# Altium schematic sheet Sheet1.SchDoc
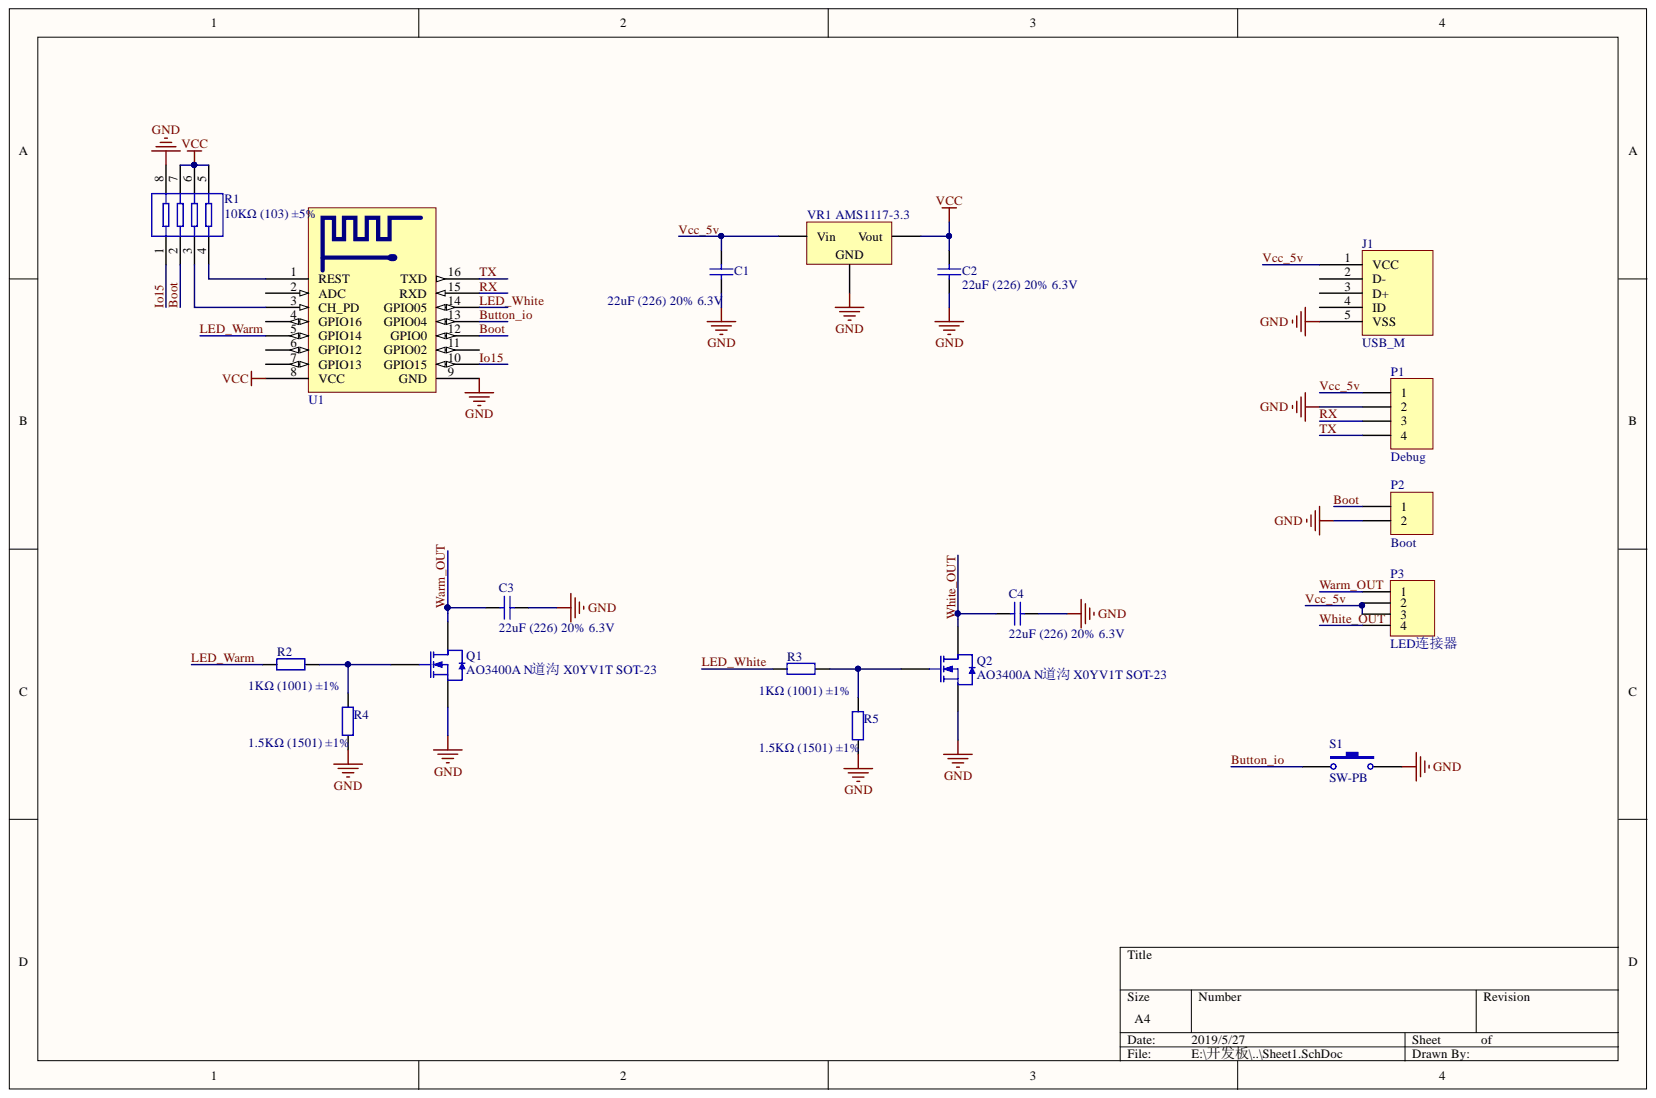
[diagram p0: Sheet1.SchDoc]

## Parts
C1  22uF (226) 20% 6.3V  [LC-0603_C]
C2  22uF (226) 20% 6.3V  [LC-0603_C]
C3  22uF (226) 20% 6.3V  [LC-0603_C]
C4  22uF (226) 20% 6.3V  [LC-0603_C]
J1  USB_M  [USB_MICRO]
P1  Debug  [HDR1X4]
P2  Boot  [HDR1X2]
P3  LED连接器  [1.25连接器]
Q1  AO3400A N道沟 X0YV1T SOT-23  [LC-SOT-23-3L]
Q2  AO3400A N道沟 X0YV1T SOT-23  [LC-SOT-23-3L]
R1  10KΩ (103) ±5%  [LC-0603_Rx4]
R2  1KΩ (1001) ±1%  [LC-0603_R]
R3  1KΩ (1001) ±1%  [LC-0603_R]
R4  1.5KΩ (1501) ±1%  [LC-0603_R]
R5  1.5KΩ (1501) ±1%  [LC-0603_R]
S1  SW-PB  [SW_PB2_A1]
U1  ESP-12  [ESP_12]  (value not drawn)
VR1  AMS1117-3.3  [LC-SOT-223]

## Nets
GND: C1.1 C2.1 C3.2 C4.2 J1.5(VSS) P1.2 P2.2 Q1.2 Q2.2 R1.8 R4.1 R5.1 S1.2 U1.9(GND) VR1.1
VCC: C2.2 R1.5 R1.6 R1.7 U1.8(VCC) VR1.2
Boot: P2.1 R1.2 U1.12(GPIO0)
Button_io: S1.1 U1.13(GPIO04)
Io15: R1.1 U1.10(GPIO15)
LED_Warm: R2.1 U1.5(GPIO14)
LED_White: R3.1 U1.14(GPIO05)
RX: P1.3 U1.15(RXD)
TX: P1.4 U1.16(TXD)
Vcc_5v: C1.2 J1.1(VCC) P1.1 P3.2 P3.3 VR1.3
Warm_OUT: C3.1 P3.1 Q1.3
White_OUT: C4.1 P3.4 Q2.3
NetQ1_1: Q1.1 R2.2 R4.2
NetQ2_1: Q2.1 R3.2 R5.2
NetR1_3: R1.3 U1.3(CH_PD)
NetR1_4: R1.4 U1.1(REST)

Not connected: J1 2(D-) 3(D+) 4(ID); U1 2(ADC) 4(GPIO16) 6(GPIO12) 7(GPIO13) 11(GPIO02)

## Library data of the parts
C1-C4 = 0603 22uF (226) 20% 6.3V: 贴片电容; Component Kind: Standard; ComponentLink1Description: 供应商链接; ComponentLink1URL: http://www.szlcsc.com/product/details_60514.html; Package: 0603; Supplier: LC; SuppliersPartNumber: C59461; Library Name: 嘉立创库.IntLib
J1 = USB_M: MicroUSB接口; Component Kind: Standard; Library Name: Fszhang.IntLib
P1 = Header 4: Header, 4-Pin; Component Kind: Standard; LatestRevisionDate: 17-Jul-2002; LatestRevisionNote: Re-released for DXP Platform.; Library Name: Miscellaneous Connectors.IntLib; Signal Integrity: Connector; Publisher: Altium Limited
P2 = Header 2: Header, 2-Pin; Component Kind: Standard; LatestRevisionDate: 17-Jul-2002; LatestRevisionNote: Re-released for DXP Platform.; Library Name: Miscellaneous Connectors.IntLib; Signal Integrity: Connector; Publisher: Altium Limited
P3 = 1.25mm连接器: Component Kind: Standard; Library Name: Schlib2.SchLib
Q1 Q2 = AO3400A N道沟 X0YV1T SOT-23: MOS(场效应管); Component Kind: Standard; ComponentLink1Description: 供应商链接; ComponentLink1URL: http://www.szlcsc.com/product/details_21629.html; Package: SOT-23; Supplier: LC; SuppliersPartNumber: C20917; Library Name: 嘉立创库.IntLib
R1 = 0603*4  10KΩ (103) 5%: 贴片排阻; Component Kind: Standard; ComponentLink1Description: 供应商链接; ComponentLink1URL: http://www.szlcsc.com/product/details_30472.html; Package: 0603*4; Supplier: LC; SuppliersPartNumber: C29718; Library Name: 嘉立创库.IntLib
R2 R3 = 0603 1KΩ (1001) 1%: 贴片电阻; Component Kind: Standard; ComponentLink1Description: 供应商链接; ComponentLink1URL: http://www.szlcsc.com/product/details_21904.html; Package: 0603; Supplier: LC; SuppliersPartNumber: C21190; Library Name: 嘉立创库.IntLib
R4 R5 = 0603 1.5KΩ (1501) 1%: 贴片电阻; Component Kind: Standard; ComponentLink1Description: 供应商链接; ComponentLink1URL: http://www.szlcsc.com/product/details_23570.html; Package: 0603; Supplier: LC; SuppliersPartNumber: C22843; Library Name: 嘉立创库.IntLib
S1 = SW-PB: 微动开关; Component Kind: Standard; LatestRevisionDate: 17-Jul-2002; LatestRevisionNote: Re-released for DXP Platform.; PackageReference: SPST-2; Published: 24-Mar-1999; Library Name: Fszhang.IntLib; Publisher: Altium Limited
VR1 = SOT-223 AMS1117-3.3: 低压差线性稳压(LDO); Component Kind: Standard; ComponentLink1Description: 供应商链接; ComponentLink1URL: http://www.szlcsc.com/product/details_6652.html; Package: SOT-223; Supplier: LC; SuppliersPartNumber: C6186; Library Name: 嘉立创库.IntLib
U1 = ESP-12: Component Kind: Standard; Library Name: ESP8266.IntLib
